# Altium schematic sheet G031_Dev.SchDoc
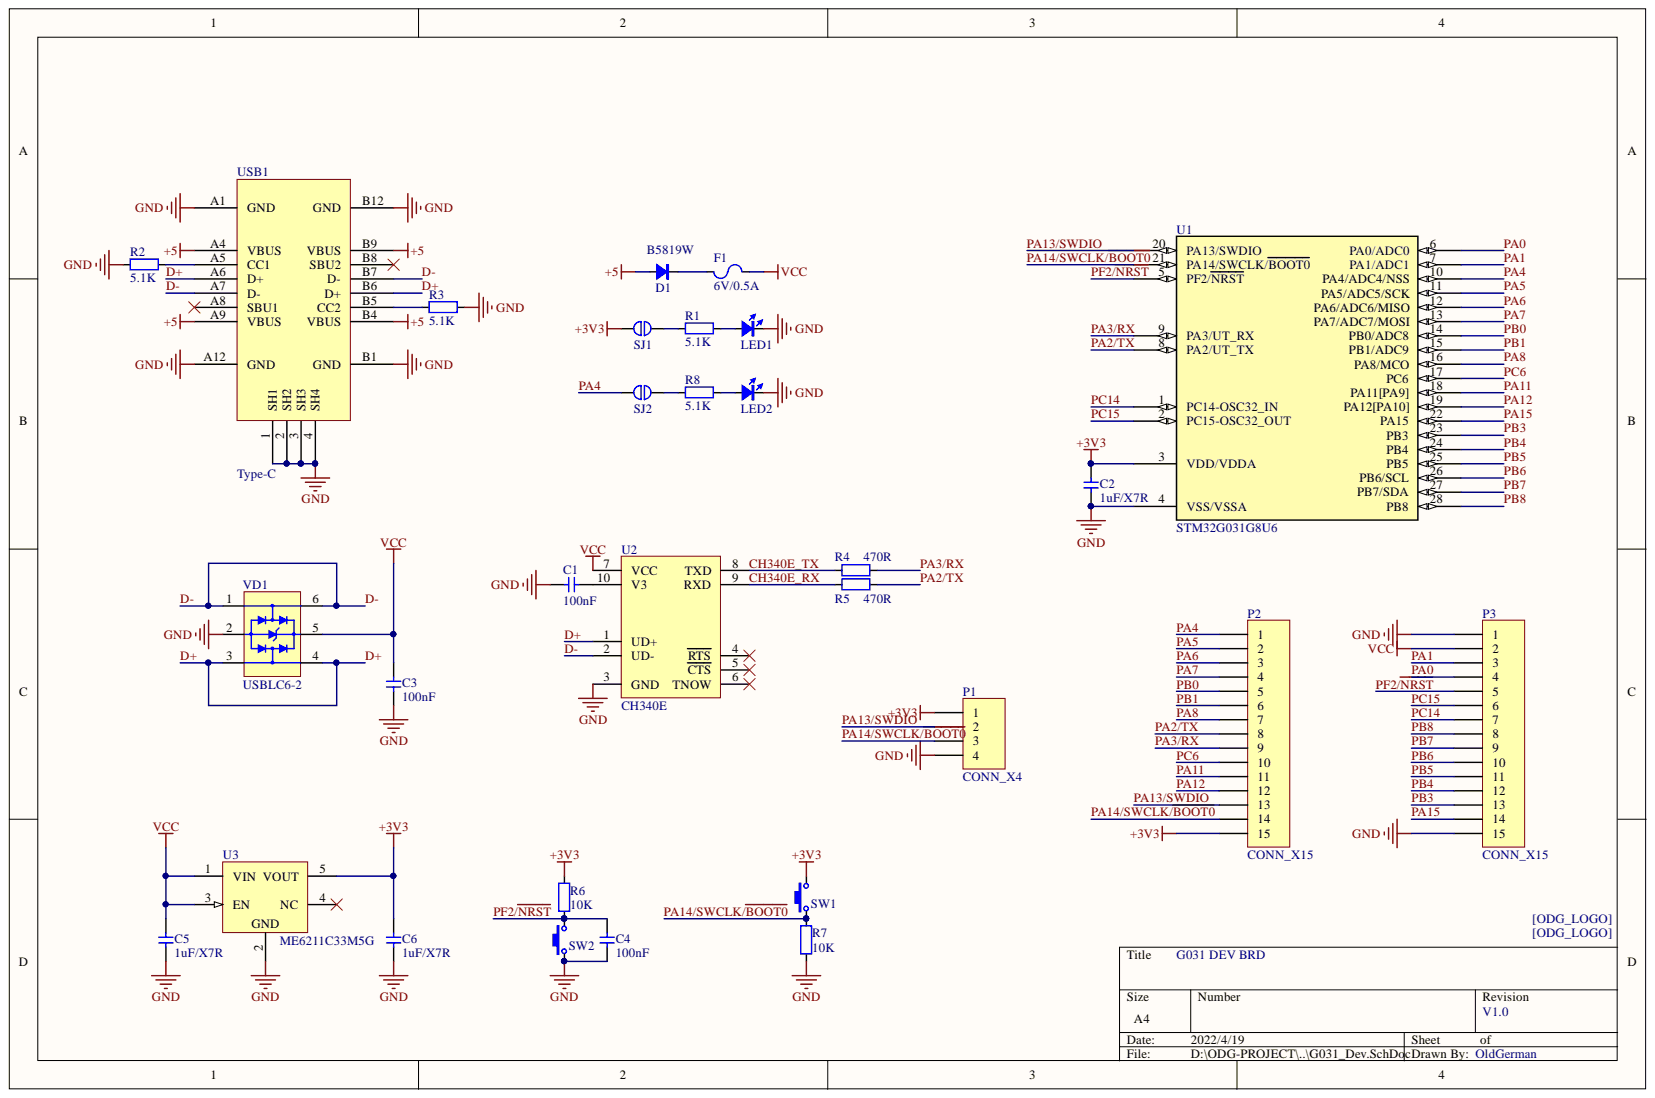
[diagram p0: G031_Dev.SchDoc]

## Parts
*  ODG_LOGO  [ODG_LOGO]
*  ODG_LOGO  [ODG_LOGO]
C1  100nF  [C0603]
C2  1uF/X7R  [C0603]
C3  100nF  [C0603]
C4  100nF  [C0603]
C5  1uF/X7R  [C0603]
C6  1uF/X7R  [C0603]
D1  B5819W  [SOD-323]
F1  6V/0.5A  [FUSE0603_0.5MM]
LED1  LED  [LED0603_GREEN]
LED2  LED  [LED0603_YELLOW]
P1  CONN_X4  [2.54mm_Male_x4]
P2  CONN_X15  [2.54MM_MALE_X15]
P3  CONN_X15  [2.54MM_MALE_X15]
R1  5.1K  [R0603]
R2  5.1K  [R0603]
R3  5.1K  [R0603]
R4  470R  [R0603]
R5  470R  [R0603]
R6  10K  [R0603]
R7  10K  [R0603]
R8  5.1K  [R0603]
SJ1  SJ_2P  [SJ_2P_MINI]  (value not drawn)
SJ2  SJ_2P  [SJ_2P_MINI]  (value not drawn)
SW1  Button-2P  [SWITCH_3X4_SMD]  (value not drawn)
SW2  Button-2P  [SWITCH_3X4_SMD]  (value not drawn)
U1  STM32G031G8U6  [UFQFPN28-4x4mm_ODG]
U2  CH340E  [TI MSOP-10 DGS]
U3  ME6211C33M5G  [ANALOG TSOT-23-5 UJ-5]
USB1  Type-C  [Type-C-TOP]
VD1  USBLC6-2  [MICROCHIP SOT-23-6 M6]

## Nets
+3V3: C2.1 C6.2 P1.1 P2.15 R6.1 SJ1.1 SW1.2 U1.3(VDD/VDDA) U3.5(VOUT)
+5: D1.1 USB1.A4(VBUS) USB1.A9(VBUS) USB1.B4(VBUS) USB1.B9(VBUS)
GND: C1.1 C2.2 C3.1 C4.1 C5.1 C6.1 LED1.2 LED2.2 P1.4 P3.1 P3.15 R2.1 R3.2 R7.2 SW2.1 U1.4(VSS/VSSA) U2.3(GND) U3.2 USB1.1(SH1) USB1.2(SH2) USB1.3(SH3) USB1.4(SH4) USB1.A1(GND) USB1.A12(GND) USB1.B1(GND) USB1.B12(GND) VD1.2
VCC: C3.2 C5.2 F1.2 P3.2 U2.7(VCC) U3.1(VIN) U3.3(EN) VD1.5
CH340E_RX: R5.1 U2.9(RXD)
CH340E_TX: R4.1 U2.8(TXD)
D+: U2.1(UD+) USB1.A6(D+) USB1.B6(D+) VD1.3 VD1.4
D-: U2.2(UD-) USB1.A7(D-) USB1.B7(D-) VD1.1 VD1.6
PA0: P3.4 U1.6(PA0/ADC0)
PA1: P3.3 U1.7(PA1/ADC1)
PA2/TX: P2.8 R5.2 U1.8(PA2/UT_TX)
PA3/RX: P2.9 R4.2 U1.9(PA3/UT_RX)
PA4: P2.1 SJ2.1 U1.10(PA4/ADC4/NSS)
PA5: P2.2 U1.11(PA5/ADC5/SCK)
PA6: P2.3 U1.12(PA6/ADC6/MISO)
PA7: P2.4 U1.13(PA7/ADC7/MOSI)
PA8: P2.7 U1.16(PA8/MCO)
PA11: P2.11 U1.18(PA11[PA9])
PA12: P2.12 U1.19(PA12[PA10])
PA13/SWDIO: P1.2 P2.13 U1.20(PA13/SWDIO)
PA14/SWCLK/~{BOOT0}: P1.3 P2.14 R7.1 SW1.1 U1.21(PA14/SWCLK/BOOT0)
PA15: P3.14 U1.22(PA15)
PB0: P2.5 U1.14(PB0/ADC8)
PB1: P2.6 U1.15(PB1/ADC9)
PB3: P3.13 U1.23(PB3)
PB4: P3.12 U1.24(PB4)
PB5: P3.11 U1.25(PB5)
PB6: P3.10 U1.26(PB6/SCL)
PB7: P3.9 U1.27(PB7/SDA)
PB8: P3.8 U1.28(PB8)
PC6: P2.10 U1.17(PC6)
PC14: P3.7 U1.1(PC14-OSC32_IN)
PC15: P3.6 U1.2(PC15-OSC32_OUT)
PF2/~{NRST}: C4.2 P3.5 R6.2 SW2.2 U1.5(PF2/NRST)
NetC1_2: C1.2 U2.10(V3)
NetD1_2: D1.2 F1.1
NetLED1_1: LED1.1 R1.2
NetLED2_1: LED2.1 R8.2
NetR1_1: R1.1 SJ1.2
NetR2_2: R2.2 USB1.A5(CC1)
NetR3_1: R3.1 USB1.B5(CC2)
NetR8_1: R8.1 SJ2.2

Not connected: U2 4(RTS) 5(CTS) 6(TNOW); U3 4(NC); USB1 A8(SBU1) B8(SBU2)

## Library data of the parts
C1-C6 = CAP: Capacitor; Value: 0.1u; Component Kind: Standard; Library Name: ODG_AD_Lib.IntLib
D1 = DIODE: 二极管; Component Kind: Standard; Library Name: ODG_AD_Lib.IntLib
F1 = FUSE: 贴片自恢复保险丝; Component Kind: Standard; Library Name: ODG_AD_Lib.IntLib
LED1 LED2 = LED: Value: color?; Component Kind: Standard; Description: LED; Library Name: ODG_AD_Lib.IntLib
R1-R8 = RES: Resistor; Value: 22R; Component Kind: Standard; Library Name: ODG_AD_Lib.IntLib
SJ1 SJ2 = SJ_2P: 焊接跳线; Component Kind: Standard; Library Name: ODG_AD_Lib.IntLib
SW1 SW2 = Button-2P: Button; Component Kind: Standard; Library Name: ODG_AD_Lib.IntLib
U1 = STM32G031G8U6: No Description Available; Mfr_Name: STMicroelectronics; Component Kind: Standard; Library Name: ODG_AD_Lib.IntLib; Manufacturer_Part_Number: STM32G031G8U6; RefDes: RefDes; Type: DEV; Copyright: Copyright (C) 2022 Ultra Librarian. All rights reserved.
U3 = ME6211C33M5G: 线性稳压芯片; BOM名称: 可调升压芯片; 版权归属: 源创客; Component Kind: Standard; 学习资源: www.ooocke.com; Library Name: ODG_AD_Lib.IntLib
USB1 = USB-Type-C_16P: Component Kind: Standard; Library Name: ODG_AD_Lib.IntLib
VD1 = USBLC6-2: Very low capacitance ESD protection  Features  • 2 data-line protection  • Protects VBUS  • Very low capacitance: 3.5 pF max.  • Very low leakage current: 150 nA max.  • SOT-666 and SOT23-6L packages  • RoHS compliant; BOM名称: 钳位IC; 属性: 30V/0.2A; 版权归属: 源创客; Component Kind: Standard; 学习资源: www.ooocke.com; Library Name: ODG_AD_Lib.IntLib
* * = ODG_LOGO: Component Kind: Standard; Library Name: ODG_AD_Lib.IntLib
P1 = CONN_X4: Component Kind: Standard; Library Name: ODG_AD_Lib.IntLib
P2 P3 = CONN_X15: Component Kind: Standard; Library Name: ODG_AD_Lib.IntLib
U2 = CH340E: Component Kind: Standard; Library Name: ODG_AD_Lib.IntLib
